# Altium schematic sheet MAIN.SchDoc(MAIN)
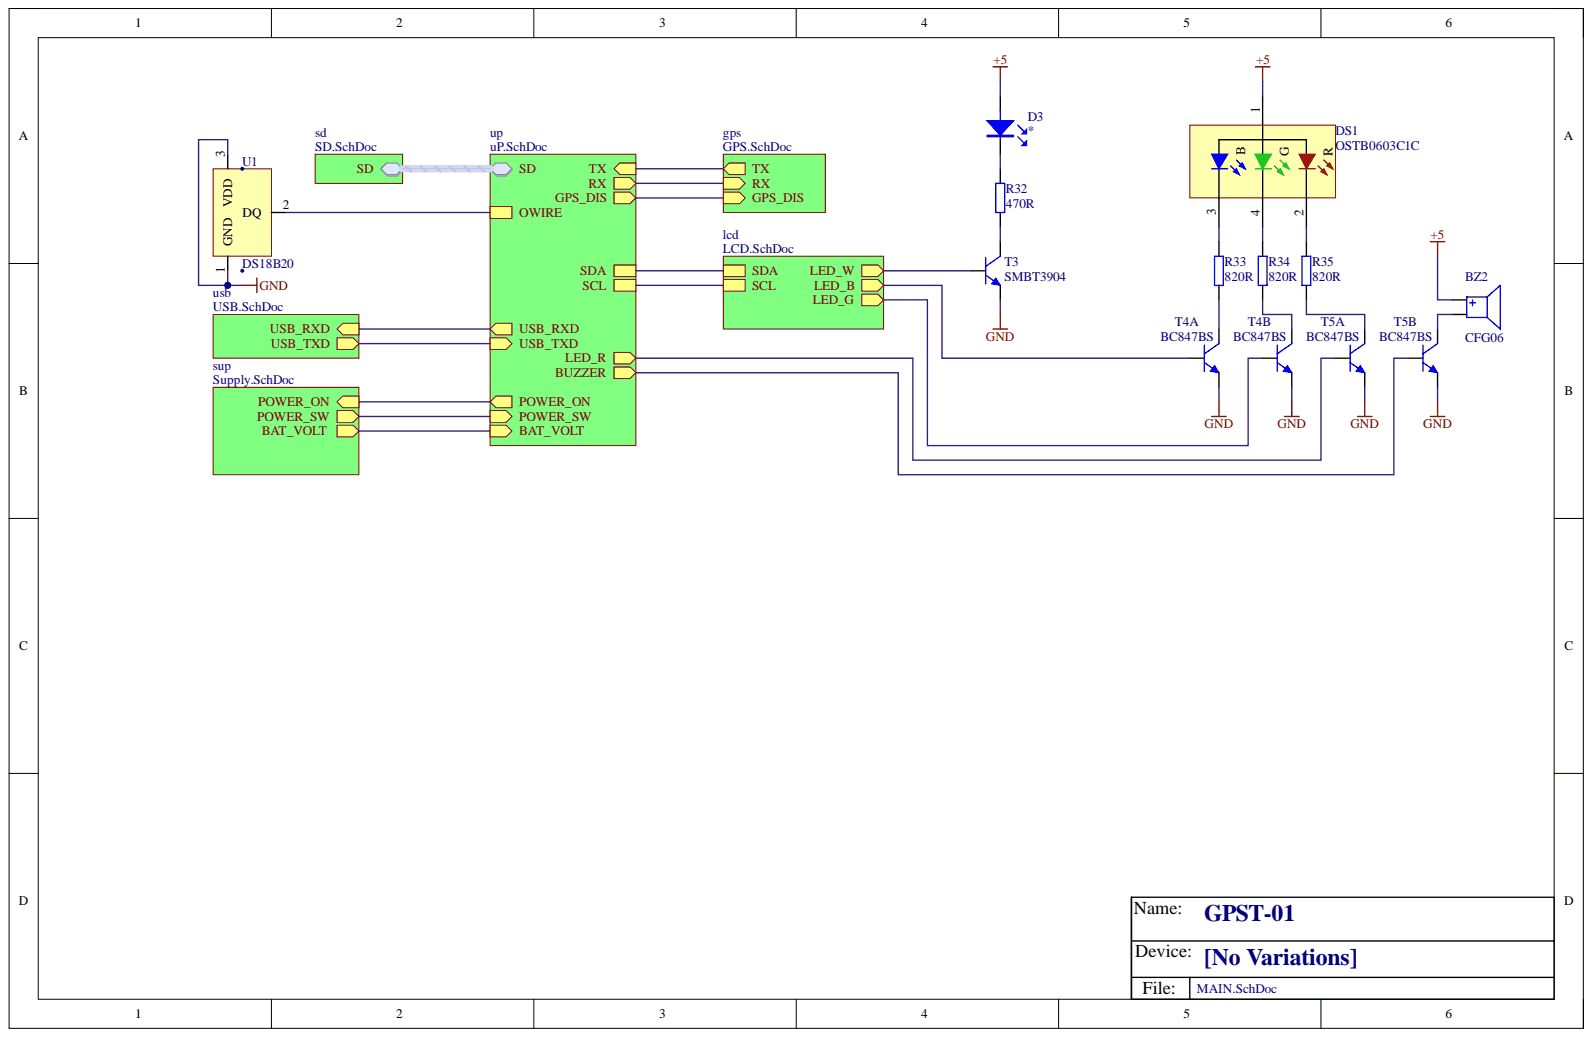
[diagram p0: MAIN.SchDoc]

## Parts
BZ2  CFG06  [CFG-06]
D3  (no value)  [LED_0805]
DS1  OSTB0603C1C  [LED0603_4]
R32  470R  [R0805]
R33  820R  [R0805]
R34  820R  [R0805]
R35  820R  [R0805]
T3  SMBT3904  [SOT23J]
T4  BC847BS  [SOT363]  (parts A,B)
T5  BC847BS  [SOT363]  (parts A,B)
U1  DS18B20  [TO-92]

## Nets
+5: BZ2.+ D3.A DS1.1
GND: T3.1 T4.1 T4.4 T5.1 T5.4 U1.1 U1.3
NetBZ2_-: BZ2.- T5.6
NetD3_K: D3.K R32.2
NetDS1_2: DS1.2 R35.1
NetDS1_3: DS1.3 R33.1
NetDS1_4: DS1.4 R34.1
NetR32_1: R32.1 T3.3
NetR33_2: R33.2 T4.3
NetR34_2: R34.2 T4.6
NetR35_2: R35.2 T5.3
NetT3_2: T3.2
NetT4_2: T4.2
NetT4_5: T4.5
NetT5_2: T5.2
NetT5_5: T5.5
NetU1_2: U1.2
BAT_VOLT:
GPS_DIS:
POWER_ON:
POWER_SW:
RX:
SCL:
SD.CD:
SD.CS:
SD.MISO:
SD.MOSI:
SD.PWROFF:
SD.SCK:
SD.WP:
SDA:
TX:
USB_RXD:
USB_TXD:

## Library data of the parts
BZ2 = CFG06: BUZZER;; Indeks: MAKB002; P&P: 0; Component Kind: Standard; Value: CFG06; Library Name: SONEL_all.IntLib
D3 = LED: LED;; Component Kind: Standard; Library Name: SONEL_all.IntLib
DS1 = OPTOSUPPLY OSTB0603C1C: LED, RGB, SMD; Indeks: MOPSL068; Obudowa: LED0603; P&P: 1; Component Kind: Standard; Value: OSTB0603C1C; Library Name: SONEL_all.IntLib
R32-R35 = R: R; resistor; Component Kind: Standard; Simulation: Model Name; Library Name: SONEL_all.IntLib
  R32: Value: 470R
  R33-R35: Value: 820R
T3 = SMBT3904: T_NPN; Bipolar Transistor; Indeks: MTRSB002; P&P: 1; Component Kind: Standard; Signal Integrity: NPN; Simulation: NPN; Value: SMBT3904; Library Name: SONEL_all.IntLib
T4 T5 = BC847BS: T_NPN; Bipolar Transistor; Indeks: MTRSB057; P&P: 1; Component Kind: Standard; Signal Integrity: NPN; Value: BC847BS; Library Name: SONEL_all.IntLib
U1 = DS18B20: temperature sensor; Indeks: MUKTC049; P&P: 0; Component Kind: Standard; Value: DS18B20; Library Name: SONEL_all.IntLib
